# Altium schematic sheet Sheet2.SchDoc(Sheet2)
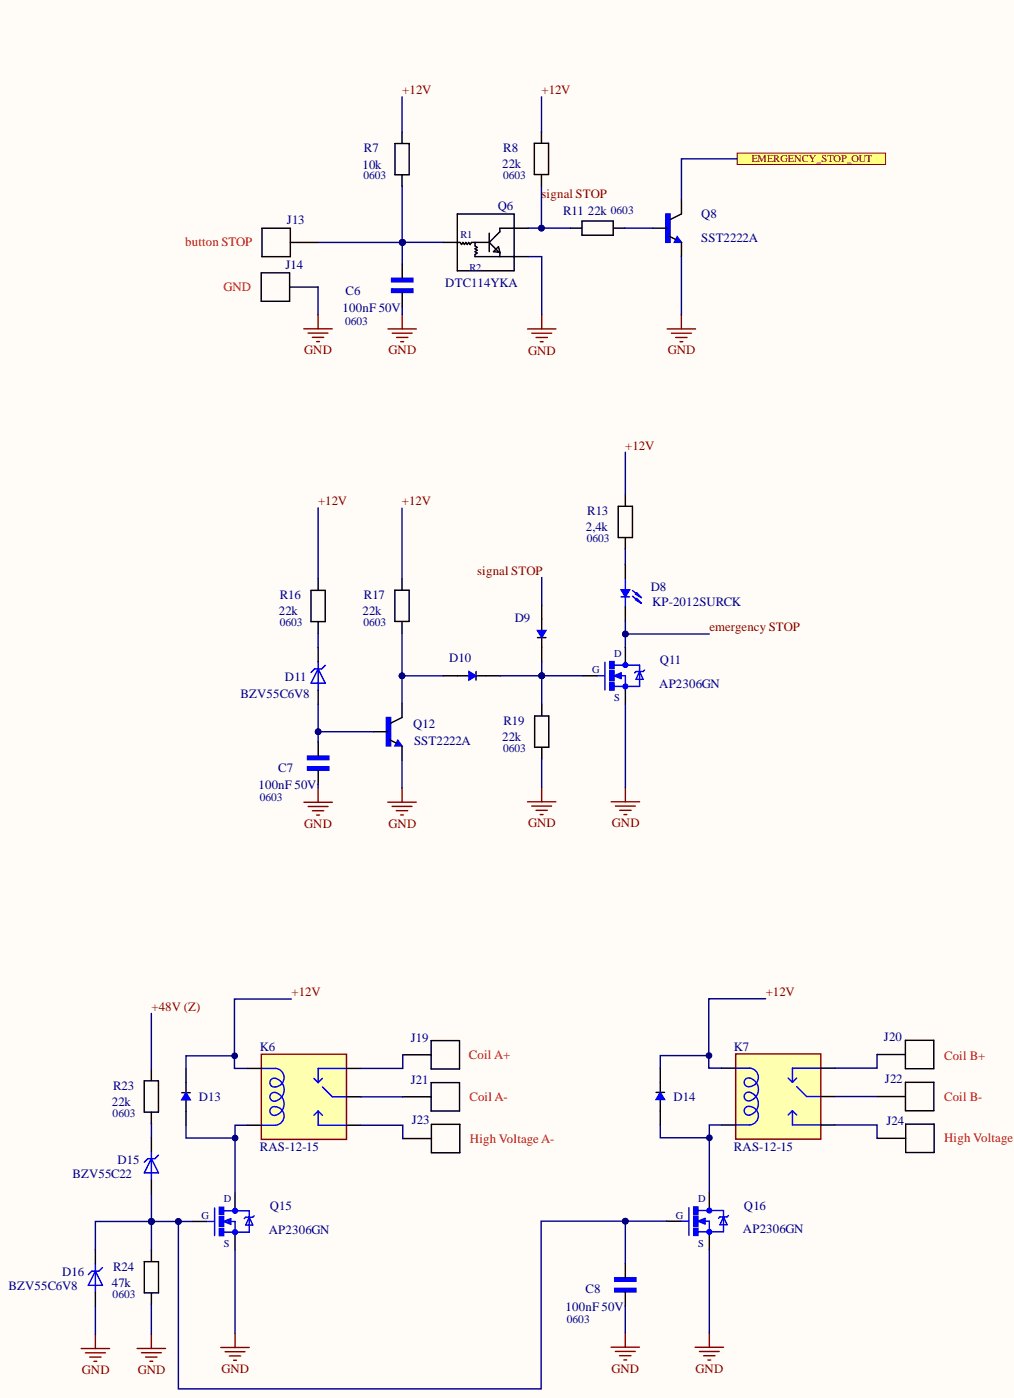
[diagram p0: Sheet2.SchDoc, left]
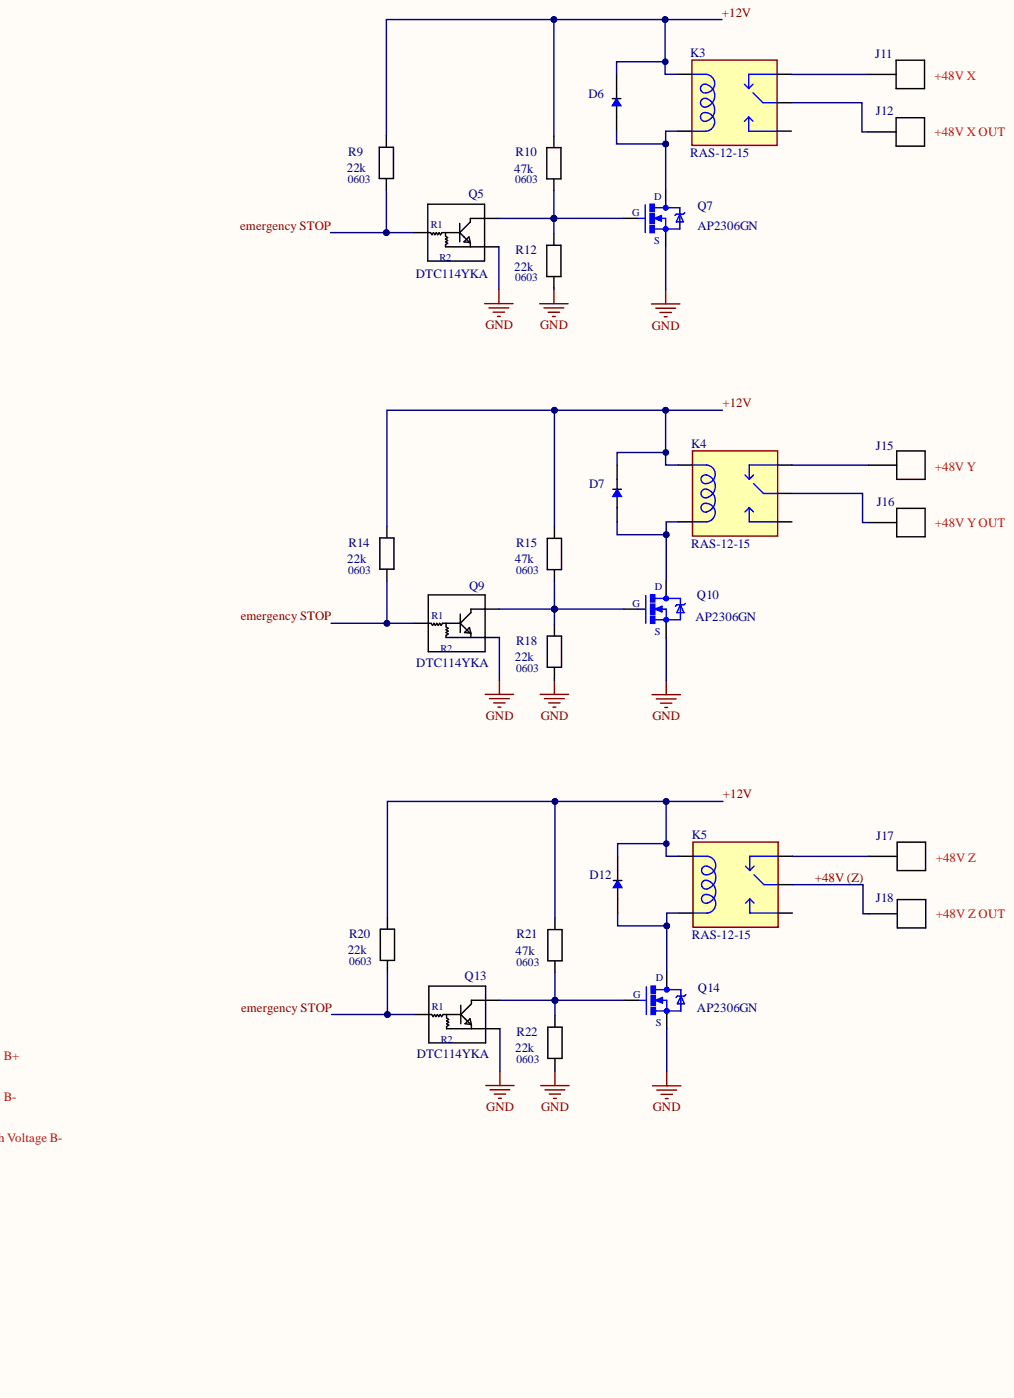
[diagram p1: Sheet2.SchDoc, right]

## Parts
C6  100nF 50V  Case: 0603  [SMD 0603 CAP]
C7  100nF 50V  Case: 0603  [SMD 0603 CAP]
C8  100nF 50V  Case: 0603  [SMD 0603 CAP]
D6  1N4148W  [SOD123]  (value not drawn)
D7  1N4148W  [SOD123]  (value not drawn)
D8  KP-2012SURCK  [SMD 0805 LED-R]
D9  1N4148W  [SOD123]  (value not drawn)
D10  1N4148W  [SOD123]  (value not drawn)
D11  BZV55C6V8  [SOD80C]
D12  1N4148W  [SOD123]  (value not drawn)
D13  1N4148W  [SOD123]  (value not drawn)
D14  1N4148W  [SOD123]  (value not drawn)
D15  BZV55C22  [SOD80C]
D16  BZV55C6V8  [SOD80C]
J11  +48V X  [Wire-2]
J12  +48V X OUT  [Wire-2]
J13  button STOP  [Wire-2]
J14  GND  [Wire-2]
J15  +48V Y  [Wire-2]
J16  +48V Y OUT  [Wire-2]
J17  +48V Z  [Wire-2]
J18  +48V Z OUT  [Wire-2]
J19  Coil A+  [Wire-2]
J20  Coil B+  [Wire-2]
J21  Coil A-  [Wire-2]
J22  Coil B-  [Wire-2]
J23  High Voltage A-  [Wire-2]
J24  High Voltage B-  [Wire-2]
K3  RAS-12-15  [RELAY RAS-12-15 (SPDT)]
K4  RAS-12-15  [RELAY RAS-12-15 (SPDT)]
K5  RAS-12-15  [RELAY RAS-12-15 (SPDT)]
K6  RAS-12-15  [RELAY RAS-12-15 (SPDT)]
K7  RAS-12-15  [RELAY RAS-12-15 (SPDT)]
Q5  DTC114YKA  [SOT-346 (SC59) Black]
Q6  DTC114YKA  [SOT-346 (SC59) Black]
Q7  AP2306GN  [SOT-23-3]
Q8  SST2222A  [SOT-23-3]
Q9  DTC114YKA  [SOT-346 (SC59) Black]
Q10  AP2306GN  [SOT-23-3]
Q11  AP2306GN  [SOT-23-3]
Q12  SST2222A  [SOT-23-3]
Q13  DTC114YKA  [SOT-346 (SC59) Black]
Q14  AP2306GN  [SOT-23-3]
Q15  AP2306GN  [SOT-23-3]
Q16  AP2306GN  [SOT-23-3]
R7  10k  Case: 0603  [SMD 0603]
R8  22k  Case: 0603  [SMD 0603]
R9  22k  Case: 0603  [SMD 0603]
R10  47k  Case: 0603  [SMD 0603]
R11  22k  Case: 0603  [SMD 0603]
R12  22k  Case: 0603  [SMD 0603]
R13  2,4k  Case: 0603  [SMD 0603]
R14  22k  Case: 0603  [SMD 0603]
R15  47k  Case: 0603  [SMD 0603]
R16  22k  Case: 0603  [SMD 0603]
R17  22k  Case: 0603  [SMD 0603]
R18  22k  Case: 0603  [SMD 0603]
R19  22k  Case: 0603  [SMD 0603]
R20  22k  Case: 0603  [SMD 0603]
R21  47k  Case: 0603  [SMD 0603]
R22  22k  Case: 0603  [SMD 0603]
R23  22k  Case: 0603  [SMD 0603]
R24  47k  Case: 0603  [SMD 0603]

## Ports
EMERGENCY_STOP_OUT

## Nets
GND: C6.1 C7.1 C8.1 D16.A J14.1 Q5.2 Q6.2 Q7.2 Q8.2 Q9.2 Q10.2 Q11.2 Q12.2 Q13.2 Q14.2 Q15.2 Q16.2 R12.2 R18.2 R19.2 R22.2 R24.2
+12V: D6.C D7.C D12.C D13.C D14.C K3.1 K4.1 K5.1 K6.1 K7.1 R7.1 R8.1 R9.1 R10.1 R13.1 R14.1 R15.1 R16.1 R17.1 R20.1 R21.1
+48V (Z): J18.1 K5.C R23.1
emergency STOP: D8.C Q5.1 Q9.1 Q11.3 Q13.1 R9.2 R14.2 R20.2
EMERGENCY_STOP_OUT: Q8.3 ; port EMERGENCY_STOP_OUT
signal STOP: D9.A Q6.3 R8.2 R11.1
NetC6_2: C6.2 J13.1 Q6.1 R7.2
NetC7_2: C7.2 D11.A Q12.1
NetC8_2: C8.2 D15.A D16.C Q15.1 Q16.1 R24.1
NetD6_A: D6.A K3.2 Q7.3
NetD7_A: D7.A K4.2 Q10.3
NetD8_A: D8.A R13.2
NetD9_C: D9.C D10.C Q11.1 R19.1
NetD10_A: D10.A Q12.3 R17.2
NetD11_C: D11.C R16.2
NetD12_A: D12.A K5.2 Q14.3
NetD13_A: D13.A K6.2 Q15.3
NetD14_A: D14.A K7.2 Q16.3
NetD15_C: D15.C R23.2
NetJ11_1: J11.1 K3.NC
NetJ12_1: J12.1 K3.C
NetJ15_1: J15.1 K4.NC
NetJ16_1: J16.1 K4.C
NetJ17_1: J17.1 K5.NC
NetJ19_1: J19.1 K6.NC
NetJ20_1: J20.1 K7.NC
NetJ21_1: J21.1 K6.C
NetJ22_1: J22.1 K7.C
NetJ23_1: J23.1 K6.NO
NetJ24_1: J24.1 K7.NO
NetQ5_3: Q5.3 Q7.1 R10.2 R12.1
NetQ8_1: Q8.1 R11.2
NetQ9_3: Q9.3 Q10.1 R15.2 R18.1
NetQ13_3: Q13.3 Q14.1 R21.2 R22.1

Not connected: K3 NO; K4 NO; K5 NO

## Library data of the parts
C6-C8 = CL32B106KLJNNNE: 100nF 50V X7R +-10%; Manufacturer: SAMSUNG ELECTRO-MECHANICS; Component Kind: Standard; HelpURL: https://store.comet.bg/Catalogue/Product/5963/; Library Name: CAN_Library.IntLib; Model: CL32B106KLJNNNE; Value: 100nF 50V
D6 D7 D9 D10 D12-D14 = 1N4148W: Diode Standard 100V 300mA _DC_ Surface Mount SOD-123; MF: DIODES / ZETEX; MP: 1N4148W-7-F; Case: SOD-123 Diodes Inc.; Component Kind: Standard; HelpURL: https://store.comet.bg/Catalogue/Product/9613/; Library Name: CAN_Library.IntLib; Value: 1N4148W
D8 = KP-2012: LED 2.0x1.25mm, 50-160 mcd@20mA, W.Clear, 120°; MF: Rohm Semiconductor; MP: SMLMN2WB1CW1C; Availability: Unavailable; Component Kind: Standard; Library Name: CAN_Library.IntLib; Package: 0805 Rohm Semiconductor; Price: None; SnapEDA_Link: https://www.snapeda.com/parts/SMLMN2WB1CW1C/Rohm/view-part/3217279/?ref=snap; Value: KP-2012SURCK
D11 D16 = BZV55C: 500 mW 5% 6.8V minimelf; MF: VISHAY; Case: SOD80C; Component Kind: Standard; Library Name: CAN_Library.IntLib; Value: BZV55C6V8
D15 = BZV55C: 500 mW 5% 22V minimelf; MF: VISHAY; Case: SOD80C; Component Kind: Standard; Library Name: CAN_Library.IntLib; Value: BZV55C22
J11-J24 = Wire: Component Kind: Standard; Description: Wire; Library Name: CAN_Library.IntLib; Value: Wire
  J11 J12 J15-J19: Case: 0,8mm
  J13 J14 J20-J24: Case: 0,8 mm
K3-K7 = RAS-12-15: RELAY 12V /30mA 15A/24VDC(120VAC) 10A/250VAC; MF: SUN HOLD; Component Kind: Standard; Library Name: CAN_Library.IntLib; Package: SPDT; Purchase-URL: https://store.comet.bg/Catalogue/Product/23849/; Value: RAS-12-15
Q5 Q6 Q9 Q13 = DTC114YKA: NPN Digital 50V 100mA 200mW  R1=10kOm R2=47kOm; Manufacturer: ROHM Semiconductor; Case: SOT-346 (SC59); Component Kind: Standard; HalpURL: https://store.comet.bg/Catalogue/Product/23715/; Library Name: CAN_Library.IntLib; Value: DTC114YKA
Q7 Q10 Q11 Q14-Q16 = AP2306GN: NMOS 20V 5.3A 1.38W 35mOhm/4.5V; 50mOhm/2.5V; MF: ADVANCED POWER ELECTR. CO; MP: AP2306GN-HF-3TR; Component Kind: Standard; Library Name: CAN_Library.IntLib; Package: SOT-23; Value: AP2306GN
Q8 Q12 = SST2222A: Transistor NPN 40V 600mA 300MHz 350mW; Manufacturer: ROHM Semiconductor; Case: SOT-23-3 SST3; Component Kind: Standard; HelpURL: https://store.comet.bg/Catalogue/Product/23715/; Library Name: CAN_Library.IntLib; Value: SST2222A
R7-R24 = Resistor_2: RES; Component Kind: Standard; HelpURL: https://store.comet.bg/Catalogue/RES/Resistors/#eyJpcHAiOiIxMDAiLCJtIjoiIn0%3D; Library Name: CAN_Library.IntLib
  R7: Value: 10k
  R8 R9 R11 R12 R14 R16-R20 R22 R23: Value: 22k
  R10 R15 R21 R24: Value: 47k
  R13: Value: 2,4k
